# Altium schematic sheet STM32F103C8T6核心板.SchDoc
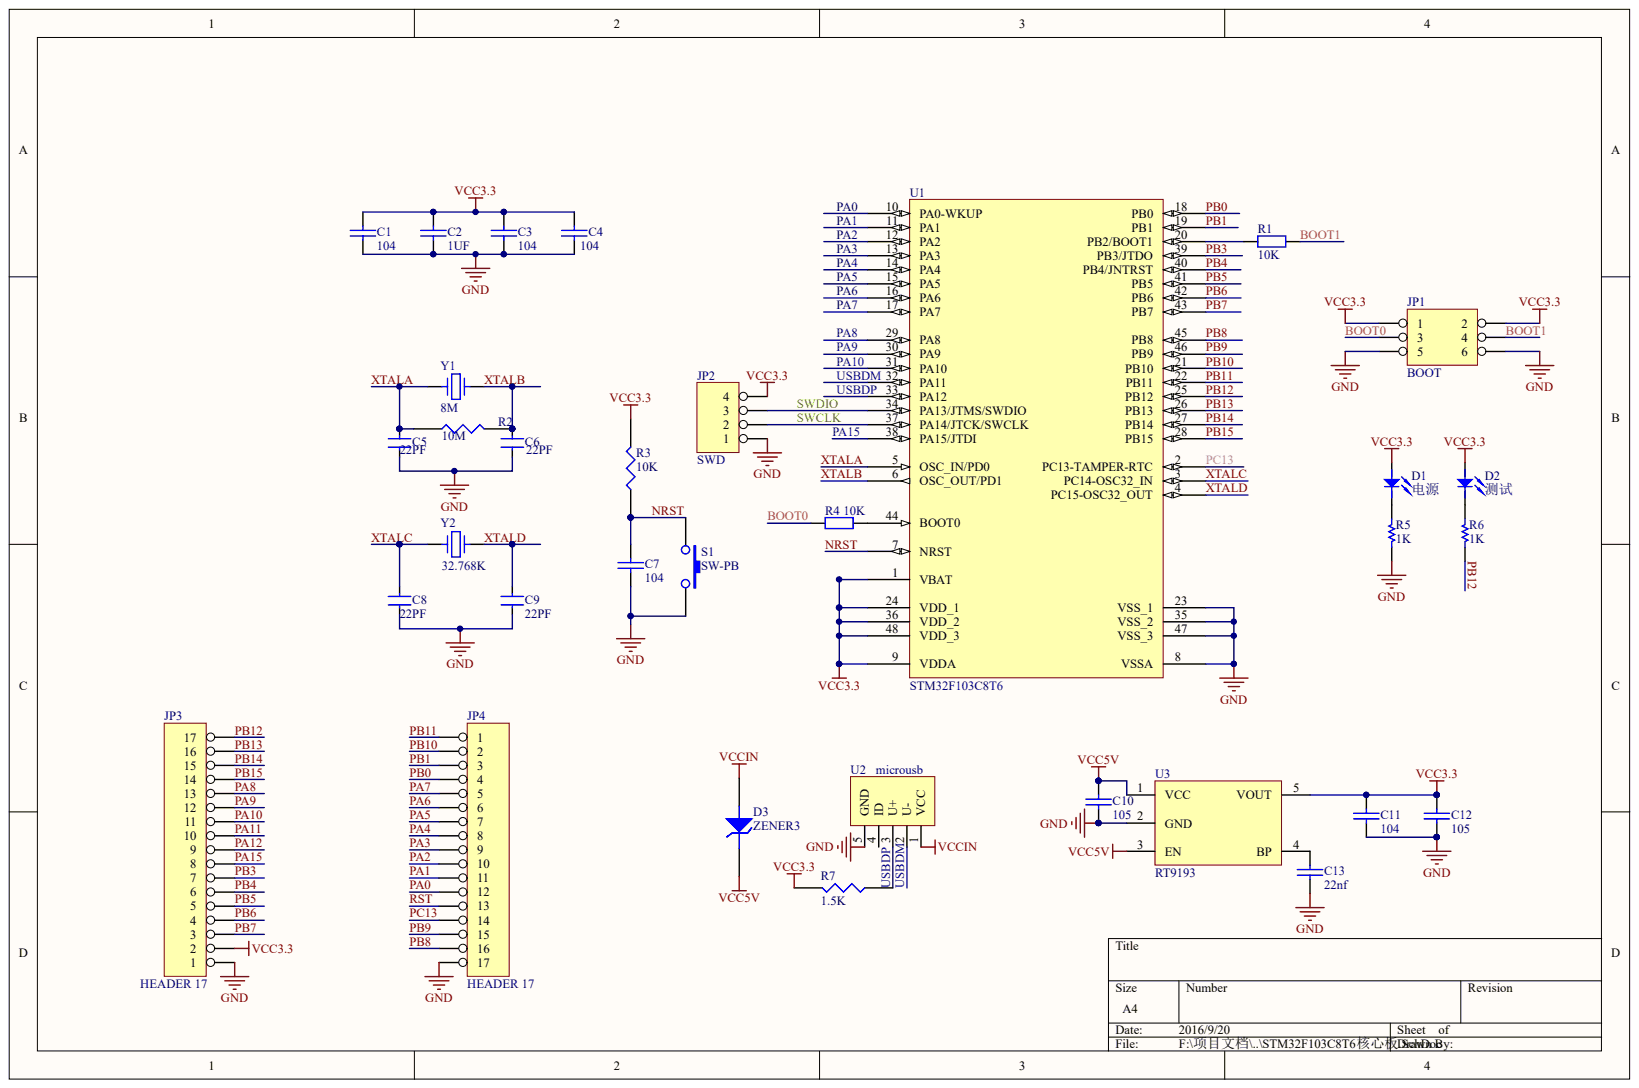
[diagram p0: STM32F103C8T6核心板.SchDoc]

## Parts
C1  104
C2  1UF
C3  104
C4  104
C5  22PF
C6  22PF
C7  104
C8  22PF
C9  22PF
C10  105
C11  104
C12  105
C13  22nf
D1  电源
D2  测试
D3  ZENER3
JP1  BOOT
JP2  SWD
JP3  HEADER 17
JP4  HEADER 17
R1  value 10K  (hidden comment: Res2)
R2  10M
R3  10K
R4  value 10K  (hidden comment: Res2)
R5  1K
R6  1K
R7  1.5K
S1  SW-PB
U1  STM32F103C8T6
U2  microusb
U3  RT9193
Y1  8M
Y2  32.768K

## Nets
GND: C1.2 C2.2 C3.2 C4.2 C5.2 C6.2 C7.2 C8.2 C9.2 C10.2 C11.2 C12.2 C13.2 JP1.5 JP1.6 JP2.1 JP3.1 JP4.17 R5.1 S1.2 U1.8(VSSA) U1.23(VSS_1) U1.35(VSS_2) U1.47(VSS_3) U2.5(GND) U3.2(GND)
VCC3.3: C1.1 C2.1 C3.1 C4.1 C11.1 C12.1 D1.A D2.A JP1.1 JP1.2 JP2.4 JP3.2 R3.2 R7.2 U1.1(VBAT) U1.9(VDDA) U1.24(VDD_1) U1.36(VDD_2) U1.48(VDD_3) U3.5(VOUT)
VCC5V: C10.1 D3.2 U3.1(VCC) U3.3(EN)
VCCIN: D3.1 U2.1(VCC)
BOOT0: JP1.3 R4.1
BOOT1: JP1.4 R1.2
NRST: C7.1 R3.1 S1.1 U1.7(NRST)
PA0: JP4.12 U1.10(PA0-WKUP)
PA1: JP4.11 U1.11(PA1)
PA2: JP4.10 U1.12(PA2)
PA3: JP4.9 U1.13(PA3)
PA4: JP4.8 U1.14(PA4)
PA5: JP4.7 U1.15(PA5)
PA6: JP4.6 U1.16(PA6)
PA7: JP4.5 U1.17(PA7)
PA8: JP3.13 U1.29(PA8)
PA9: JP3.12 U1.30(PA9)
PA10: JP3.11 U1.31(PA10)
PA11: JP3.10
PA12: JP3.9
PA15: JP3.8 U1.38(PA15/JTDI)
PB0: JP4.4 U1.18(PB0)
PB1: JP4.3 U1.19(PB1)
PB3: JP3.7 U1.39(PB3/JTDO)
PB4: JP3.6 U1.40(PB4/JNTRST)
PB5: JP3.5 U1.41(PB5)
PB6: JP3.4 U1.42(PB6)
PB7: JP3.3 U1.43(PB7)
PB8: JP4.16 U1.45(PB8)
PB9: JP4.15 U1.46(PB9)
PB10: JP4.2 U1.21(PB10)
PB11: JP4.1 U1.22(PB11)
PB12: JP3.17 R6.1 U1.25(PB12)
PB13: JP3.16 U1.26(PB13)
PB14: JP3.15 U1.27(PB14)
PB15: JP3.14 U1.28(PB15)
PC13: JP4.14 U1.2(PC13-TAMPER-RTC)
RST: JP4.13
SWCLK: JP2.2 U1.37(PA14/JTCK/SWCLK)
SWDIO: JP2.3 U1.34(PA13/JTMS/SWDIO)
USBDM: U1.32(PA11) U2.2(U-)
USBDP: R7.1 U1.33(PA12) U2.3(U+)
XTALA: C5.1 R2.1 U1.5(OSC_IN/PD0) Y1.2
XTALB: C6.1 R2.2 U1.6(OSC_OUT/PD1) Y1.1
XTALC: C8.1 U1.3(PC14-OSC32_IN) Y2.2
XTALD: C9.1 U1.4(PC15-OSC32_OUT) Y2.1
NetC13_1: C13.1 U3.4(BP)
NetD1_K: D1.K R5.2
NetD2_K: D2.K R6.2
NetR1_1: R1.1 U1.20(PB2/BOOT1)
NetR4_2: R4.2 U1.44(BOOT0)

Not connected: U2 4(ID)

## Library data of the parts
C1-C13 D1-D3 JP1-JP4 R1-R7 S1 U1-U3 Y1 Y2 = (no symbol name)
  R1 R4: Published: 8-Jun-2000; LatestRevisionDate: 17-Jul-2002; LatestRevisionNote: Re-released for DXP Platform.; PackageReference: AXIAL-0.4; PackageDescription: Axial Device, Thru-Hole; 2 Leads; 0.4 in Pin Spacing
  R5 R6: Published: 8-Jun-2000; LatestRevisionDate: 17-Jul-2002; LatestRevisionNote: Re-released for DXP Platform.
  U1: Published: *; DatasheetVersion: *; PackageVersion: *; PackageReference: *; PackageDescription: *; ComponentLink1Description: *; ComponentLink1URL: *; ComponentLink2Description: *; ComponentLink2URL: *; Publisher: *
  R1 R4-R6: Publisher: Altium Limited
